# Altium schematic sheet PMSM_500W_Controller_DC.SchDoc
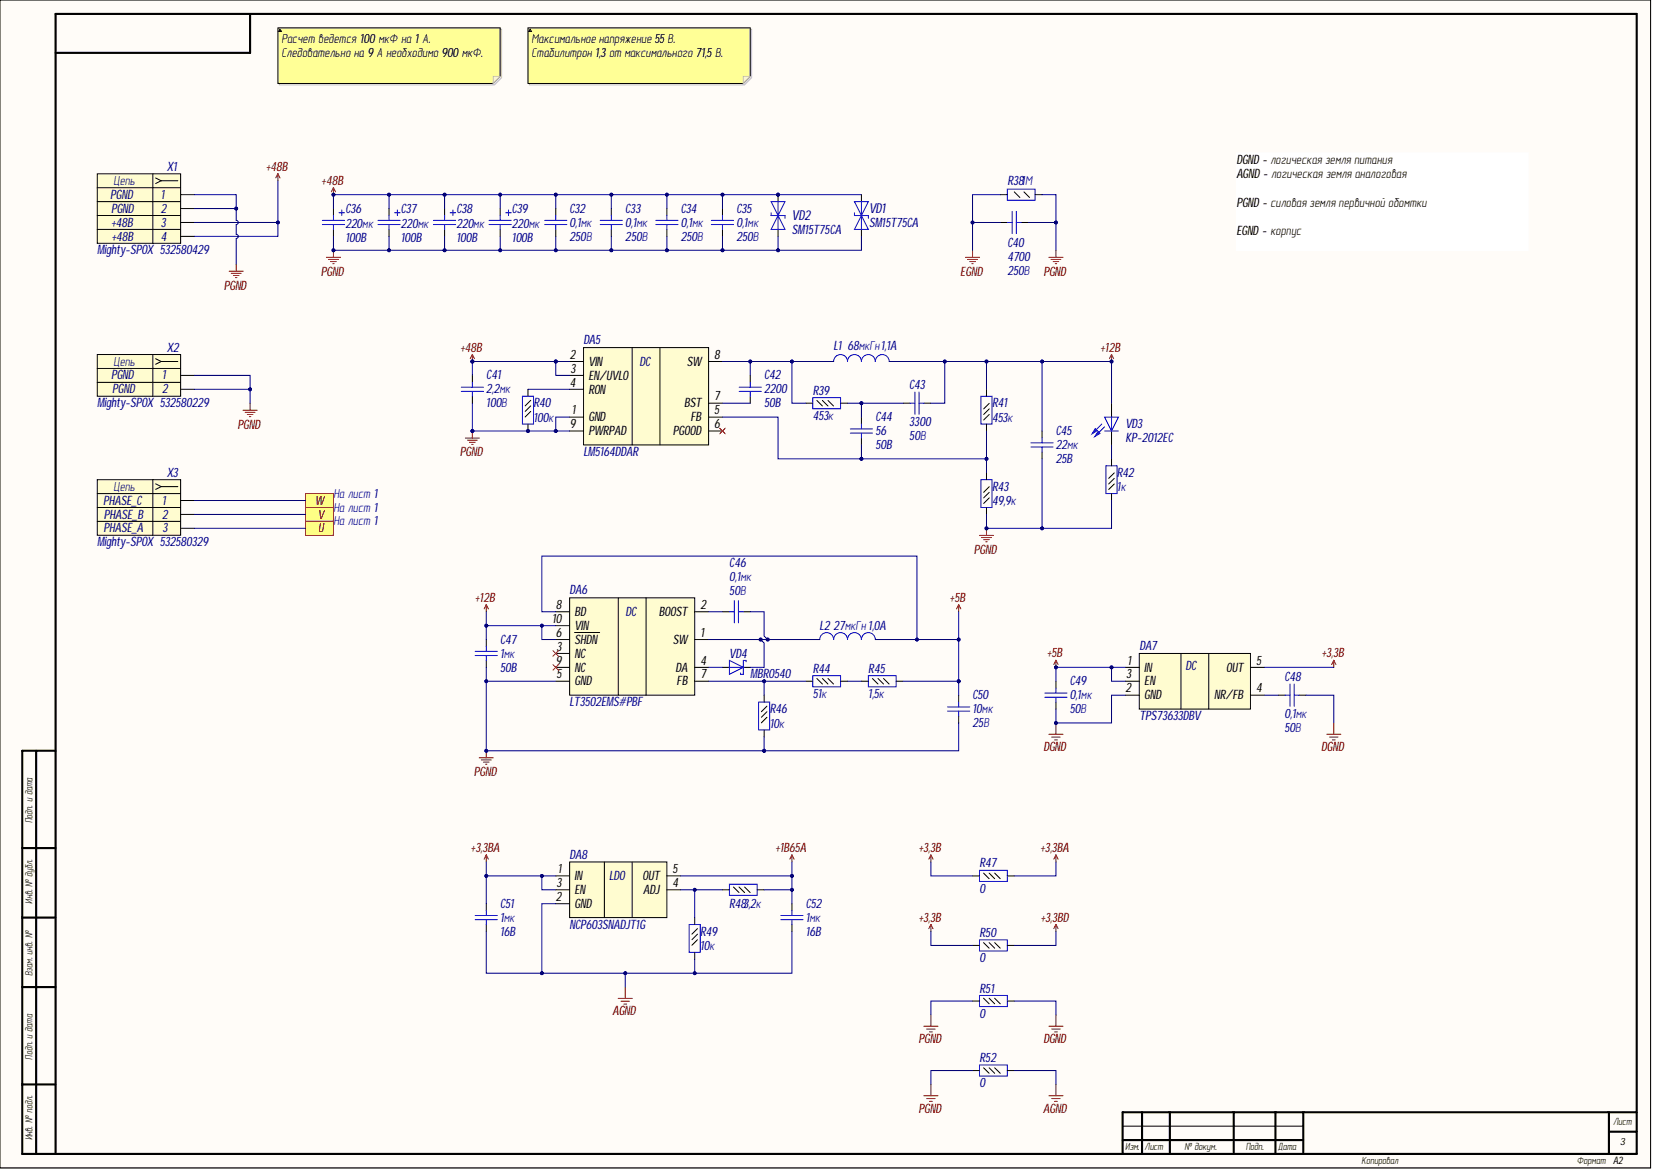
[diagram p0: PMSM_500W_Controller_DC.SchDoc]

## Parts
C32  value 0,1мк  Voltage: 250В  [C1206]  (hidden comment: Capacitor)
C33  value 0,1мк  Voltage: 250В  [C1206]  (hidden comment: Capacitor)
C34  value 0,1мк  Voltage: 250В  [C1206]  (hidden comment: Capacitor)
C35  value 0,1мк  Voltage: 250В  [C1206]  (hidden comment: Capacitor)
C36  value 220мк  Voltage: 100B  [K50-35(12.5X25)_V]  (hidden comment: Capacitor_pol)
C37  value 220мк  Voltage: 100B  [K50-35(12.5X25)_V]  (hidden comment: Capacitor_pol)
C38  value 220мк  Voltage: 100B  [K50-35(12.5X25)_V]  (hidden comment: Capacitor_pol)
C39  value 220мк  Voltage: 100B  [K50-35(12.5X25)_V]  (hidden comment: Capacitor_pol)
C40  value 4700  [C0805]  (hidden comment: Capacitor)
C41  2,2мк  Voltage: 100В  [C1210]
C42  value 2200  Voltage: 50B  [C0402]  (hidden comment: Capacitor)
C43  value 3300  Voltage: 50В  [C0402]  (hidden comment: Capacitor)
C44  value 56  Voltage: 50B  [C0402]  (hidden comment: Capacitor)
C45  value 22мк  Voltage: 25B  [C1210]  (hidden comment: Capacitor)
C46  value 0,1мк  Voltage: 50В  [C0402]  (hidden comment: Capacitor)
C47  value 1мк  Voltage: 50B  [C0805]  (hidden comment: Capacitor)
C48  value 0,1мк  Voltage: 50В  [C0402]  (hidden comment: Capacitor)
C49  value 0,1мк  Voltage: 50В  [C0402]  (hidden comment: Capacitor)
C50  value 10мк  Voltage: 25В  [C1206]  (hidden comment: Capacitor)
C51  value 1мк  Voltage: 16B  [C0603]  (hidden comment: Capacitor)
C52  value 1мк  Voltage: 16B  [C0603]  (hidden comment: Capacitor)
DA5  LM5164  Part Number: LM5164DDAR  [SO Powerpad8]
DA6  Part Number: LT3502EMS#PBF  [MSOP10]  (hidden comment: LT3502_MS)
DA7  Part Number: TPS73633DBV  [SOT23-5]  (hidden comment: TPS736DBV)
DA8  NCP603  Part Number: NCP603SNADJT1G  [TSOP5]
L1  Inductor  [SDR1006]  (value not drawn)
L2  value 27мкГн  Rated Current: 1,0A  [SDR0604]  (hidden comment: Inductor)
R38  value 1М  [R0805]  (hidden comment: Resistor_0,125Вт)
R39  value 453к  [R0402]  (hidden comment: Resistor_0,062Вт)
R40  value 100к  [R0402]  (hidden comment: Resistor_0,062Вт)
R41  value 453к  [R0402]  (hidden comment: Resistor_0,062Вт)
R42  value 1к  [R0402]  (hidden comment: Resistor_0,062Вт)
R43  value 49,9к  [R0402]  (hidden comment: Resistor_0,062Вт)
R44  value 51к  [R0402]  (hidden comment: Resistor_0,062Вт)
R45  value 1,5к  [R0402]  (hidden comment: Resistor_0,062Вт)
R46  value 10к  [R0402]  (hidden comment: Resistor_0,062Вт)
R47  value 0  [R0603]  (hidden comment: Resistor_0,062Вт)
R48  value 3,2к  [R0402]  (hidden comment: Resistor_0,062Вт)
R49  value 10к  [R0402]  (hidden comment: Resistor_0,062Вт)
R50  value 0  [R0603]  (hidden comment: Resistor_0,062Вт)
R51  value 0  [R0603]  (hidden comment: Resistor_0,062Вт)
R52  value 0  [R0603]  (hidden comment: Resistor_0,062Вт)
VD1  DiodeZenerDual  [SMC]  (value not drawn)
VD2  DiodeZenerDual  [SMC]  (value not drawn)
VD3  Part Number: KP-2012EC  [L_0805]  (hidden comment: LED)
VD4  Part Number: MBR0540  [SOD-123]  (hidden comment: DiodeSchottky)
X1  Contact_1: PGND  Contact_3: +48B  Part Name: Mighty-SPOX  Part Number: 532580429  [MOLEX_532580429]  (hidden comment: Header_4_sct)
X2  Contact_1: PGND  Part Name: Mighty-SPOX  Part Number: 532580229  [MOLEX_532580229]  (hidden comment: Header_2_sct)
X3  Contact_1: PHASE_C  Contact_2: PHASE_B  Contact_3: PHASE_A  Part Name: Mighty-SPOX  Part Number: 532580329  [MOLEX_532580329]  (hidden comment: Header_3_sct)

## Ports
U, V, W

## Nets
+1B65A: C52.2 DA8.5(OUT) R48.2
+3,3B: DA7.5(OUT) R47.1 R50.1
+3,3BA: C51.2 DA8.1(IN) DA8.3(EN) R47.2
+3,3BD: R50.2
+5B: C49.1 C50.2 DA6.8(BD) DA7.1(IN) DA7.3(EN) L2.2 R45.2
+12B: C43.2 C45.2 C47.2 DA6.6(SHDN) DA6.10(VIN) L1.2 R41.2 VD3.2
+48B: C32.2 C33.2 C34.2 C35.2 C36.1 C37.1 C38.1 C39.1 C41.2 DA5.2(VIN) DA5.3(EN/UVLO) VD1.1 VD2.2 X1.3 X1.4
AGND: C51.1 C52.1 DA8.2(GND) R49.2 R52.2
DGND: C48.2 C49.2 DA7.2(GND) R51.2
EGND: C40.2 R38.2
PGND: C32.1 C33.1 C34.1 C35.1 C36.2 C37.2 C38.2 C39.2 C40.1 C41.1 C45.1 C47.1 C50.1 DA5.1(GND) DA5.9(PWRPAD) DA6.5(GND) R38.1 R40.2 R42.1 R43.1 R46.2 R51.1 R52.1 VD1.2 VD2.1 X1.1 X1.2 X2.1 X2.2
U: X3.3 ; port U
V: X3.2 ; port V
W: X3.1 ; port W
NetC42_1: C42.1 DA5.7(BST)
NetC42_2: C42.2 DA5.8(SW) L1.1 R39.1
NetC43_1: C43.1 C44.2 R39.2
NetC44_1: C44.1 DA5.5(FB) R41.1 R43.2
NetC46_1: C46.1 DA6.1(SW) L2.1 VD4.1
NetC46_2: C46.2 DA6.2(BOOST)
NetC48_1: C48.1 DA7.4(NR/FB)
NetDA5_4: DA5.4(RON) R40.1
NetDA6_4: DA6.4(DA) VD4.2
NetDA6_7: DA6.7(FB) R44.1 R46.1
NetDA8_4: DA8.4(ADJ) R48.1 R49.1
NetR42_2: R42.2 VD3.1
NetR44_2: R44.2 R45.1

Not connected: DA5 6(PGOOD); DA6 3(NC) 9(NC)

## Library data of the parts
C32-C35 = cap179: Конденсатор; Manufacture: Murata; Part Name: Ceramic; Part Number: GRM31CR72E104K; Database Table Name: Capacitors$; TKE: X7R; Component Kind: Standard; id: cap179; Library Name: MOP44.DbLib
C36-C39 = cap279: Конденсатор полярный; Manufacture: Epcos; Part Name: Electrolit; Part Number: B41851A9227M000; Database Table Name: Capacitors$; ESR: 75мОм; Iac max: 0,4А; Iac rated: 0,6А; tga: 0,10%; Component Kind: Standard; id: cap279; Library Name: MOP44.DbLib
C40 = cap211: Конденсатор; Manufacture: Murata; Part Name: Ceramic; Part Number: GRM21AR72E472KW; Database Table Name: Capacitors$; TKE: X7R; Voltage: 250В; Component Kind: Standard; id: cap211; Library Name: MOP44.DbLib
C41 = cap288: Конденсатор; Manufacture: Murata; Part Name: Ceramic; Part Number: GCJ32DR72A225KA01L; Database Table Name: Capacitors$; tga: 0,10%; TKE: X7R; Component Kind: Standard; id: cap288; Library Name: MOP44.DbLib; Value: 2,2мк
C42 = cap34: Конденсатор; Manufacture: Murata; Part Name: Ceramic; Part Number: GRM155R71H222K; Database Table Name: Capacitors$; TKE: X7R; Component Kind: Standard; id: cap34; Library Name: MOP44.DbLib
C43 = cap283: Конденсатор; Manufacture: Murata; Part Name: Ceramic; Part Number: GRM155R71H332KA01D; Database Table Name: Capacitors$; tga: 0,10%; TKE: NP0; Component Kind: Standard; id: cap283; Library Name: MOP44.DbLib
C44 = cap16: Конденсатор; Manufacture: Murata; Part Name: Ceramic; Part Number: GRM1555C1H560J*; Database Table Name: Capacitors$; TKE: NP0; Component Kind: Standard; id: cap16; Library Name: MOP44.DbLib
C45 = cap280: Конденсатор; Manufacture: Taiyo Yuden; Part Name: MLCC; Part Number: TMK325B7226KMHT; Database Table Name: Capacitors$; tga: 0,10%; TKE: X7R; Component Kind: Standard; id: cap280; Library Name: MOP44.DbLib
C46 C48 C49 = cap277: Конденсатор; Manufacture: Murata; Part Name: Ceramic; Part Number: GRM155R71H104KE14D; Database Table Name: Capacitors$; tga: 0,10%; TKE: X7R; Component Kind: Standard; id: cap277; Library Name: MOP44.DbLib
C47 = cap151: Конденсатор; Manufacture: Murata; Part Name: Ceramic; Part Number: GRM21BR71H105K; Database Table Name: Capacitors$; TKE: X7R; Component Kind: Standard; id: cap151; Library Name: MOP44.DbLib
C50 = cap186: Конденсатор; Manufacture: Murata; Part Name: Ceramic; Part Number: GRM31CR71E106KA12L*; Database Table Name: Capacitors$; TKE: X7R; Component Kind: Standard; id: cap186; Library Name: MOP44.DbLib
C51 C52 = cap133: Конденсатор; Manufacture: Murata; Part Name: Ceramic; Part Number: GRM188R71C105KA12D**; Database Table Name: Capacitors$; TKE: X7R; Component Kind: Standard; id: cap133; Library Name: MOP44.DbLib
DA5 = pwr102: 1-A synchronous buck DC/DC converter with ultra-low IQ; Manufacturer: Texas Instruments; Current: 1A; Database Table Name: PowerTransducer$; Frequency: 1MHz; Input Voltage: 6B-100B; Output Voltage: 1,2B-90B; Component Kind: Standard; id: pwr102; Library Name: MOP44.DbLib; Part Name: 1-A synchronous buck DC/DC converter with ultra-low IQ; Type: Impulse
DA6 = pwr112: 1.1MHz/2.2MHz, 500mA  Step-Down Regulators; Manufacturer: Linear Technology; Part Name: 1-A synchronous buck DC/DC converter with ultra-low IQ; Current: 0,5А; Database Table Name: PowerTransducer$; Frequency: 2,2MHz; Input Voltage: 40B; Output Voltage: Adj; Component Kind: Standard; id: pwr112; Library Name: MOP44.DbLib; Type: Impulse
DA7 = pwr113: 400-mA Low-Dropout Regulator with Reverse Current  Protection; Manufacturer: Texas Instruments; Current: 400mA; Database Table Name: PowerTransducer$; Dropout Voltage: 75mB; Input Voltage: 1,7-5,5В; Output Voltage: 3,3B; Component Kind: Standard; id: pwr113; Library Name: MOP44.DbLib; Part Name: Low-Dropout Regulator with Reverse Current Protection; Type: Linear
DA8 = pwr124: High Performance  CMOS LDO Regulator with  Enable and Enhanced ESD  Protection; Manufacturer: ON Semiconductor; Part Name: High Performance LDO Regulator with ESD Protection; Current: 300mA; Database Table Name: PowerTransducer$; Dropout Voltage: 175mB; Input Voltage: 1,7-6В; Output Voltage: Adj; Component Kind: Standard; id: pwr124; Library Name: MOP44.DbLib; Type: Linear
L1 = ind109: EMI Suppression Filter; Manufacture: Bourns; Part Name: SMD Power Inductors; Part Number: SDR1006- 680KL; Database Table Name: Inductor$; Rated Current: 1,1A; Resistance: 0,22Ohm; Saturation Current: 2,0A; Value: 68мкГн; Component Kind: Standard; id: ind109; Library Name: MOP44.DbLib
L2 = ind47: EMI Suppression Filter; Manufacture: Bourns; Part Name: SMD Power Inductors; Part Number: SDR0604- 270YL; Database Table Name: Inductor$; Resistance: 0,22Ohm; Saturation Current: 1,3A; Component Kind: Standard; id: ind47; Library Name: MOP44.DbLib
R38 = res149: Резистор; Manufacture: Yageo; Part Number: RC0805FR-071ML; Accuracy: 0,01; Database Table Name: Resistor$; Component Kind: Standard; id: res149; Library Name: MOP44.DbLib; Part Name: Resistor; Power: 0,125Вт
R39 R41 = res1155: Резистор; Manufacture: Yageo; Part Number: RC0402FR-07453KL; Accuracy: 0,01; Database Table Name: Resistor$; Component Kind: Standard; id: res1155; Library Name: MOP44.DbLib; Part Name: Resistor; Power: 0,062Вт
R40 = res318: Резистор; Manufacture: Yageo; Part Number: RC0402FR-07100KL; Accuracy: 0,01; Database Table Name: Resistor$; Component Kind: Standard; id: res318; Library Name: MOP44.DbLib; Part Name: Resistor; Power: 0,062Вт
R42 = res270: Резистор; Manufacture: Yageo; Part Number: RC0402FR-071KL; Accuracy: 0,01; Database Table Name: Resistor$; Component Kind: Standard; id: res270; Library Name: MOP44.DbLib; Part Name: Resistor; Power: 0,062Вт
R43 = res1159: Резистор; Manufacture: Yageo; Part Number: RC0402FR-0749K9L; Accuracy: 0,01; Database Table Name: Resistor$; Component Kind: Standard; id: res1159; Library Name: MOP44.DbLib; Part Name: Resistor; Power: 0,062Вт
R44 = res311: Резистор; Manufacture: Yageo; Part Number: RC0402FR-0751KL; Accuracy: 0,01; Database Table Name: Resistor$; Component Kind: Standard; id: res311; Library Name: MOP44.DbLib; Part Name: Resistor; Power: 0,062Вт
R45 = res274: Резистор; Manufacture: Yageo; Part Number: RC0402FR-071K5; Accuracy: 0,01; Database Table Name: Resistor$; Component Kind: Standard; id: res274; Library Name: MOP44.DbLib; Part Name: Resistor; Power: 0,062Вт
R46 R49 = res294: Резистор; Manufacture: Yageo; Part Number: RC0402FR-0710KL; Accuracy: 0,01; Database Table Name: Resistor$; Component Kind: Standard; id: res294; Library Name: MOP44.DbLib; Part Name: Resistor; Power: 0,062Вт
R47 R50-R52 = res390: Резистор; Manufacture: Yageo; Part Number: RC0603FR-070RL; Accuracy: 0,01; Database Table Name: Resistor$; Component Kind: Standard; id: res390; Library Name: MOP44.DbLib; Part Name: Resistor; Power: 0,062Вт
R48 = res1170: Резистор; Manufacture: Yageo; Part Number: RT0402BRD073K2L; Accuracy: 0,01; Database Table Name: Resistor$; Component Kind: Standard; id: res1170; Library Name: MOP44.DbLib; Part Name: Resistor; Power: 0,062Вт
VD1 VD2 = SM15T75CA: Cтабилитрон (диод выпрямительный лавинный) двусторонний; Manufacture: ST Microelectronics; Part Name: TVS diode Bidirectional; Breakdown Voltage: 75B; Clamping voltage: 78.8B; Database Table Name: TVSdiode$; id: tvs280; Leakage Current: 0.2uA; Number of diodes: 1; Peak Pulse Power: 1500W; Stand-off Voltage: 71.3B; Component Kind: Standard; Library Name: MOP44.DbLib; Part Number: SM15T75CA
VD3 = diode5: Светодиод; Database Table Name: Diode$; Component Kind: Standard; id: diode5; Library Name: MOP44.DbLib; Part Name: LED
VD4 = diode15: Диод Шоттки; Manufacture: ON Semiconductor; Capacitance: 30pF; Database Table Name: Diode$; Forward Current: 0,5А; Forward Voltage: 0,62B; Max Reverse Voltage: 40B; Number of Channels: 1; Component Kind: Standard; id: diode15; Library Name: MOP44.DbLib; Part Name: Diode Schottky
X1 = connect121: Разъем - 4 конт. розетка; Manufacture: Molex; Database Table Name: Connector$; Etch: 3.5mm; Component Kind: Standard; Contact_2: PGND; Contact_4: +48B; id: connect121; Library Name: MOP44.DbLib
X2 = connect105: Разъем - 2 конт. розетка; Manufacture: Molex; Database Table Name: Connector$; Etch: 3.5mm; Component Kind: Standard; Contact_2: PGND; id: connect105; Library Name: MOP44.DbLib
X3 = connect104: Разъем - 3 конт. розетка; Manufacture: Molex; Database Table Name: Connector$; Etch: 3.5mm; Component Kind: Standard; id: connect104; Library Name: MOP44.DbLib
